# Altium schematic sheet Schematic.SchDoc
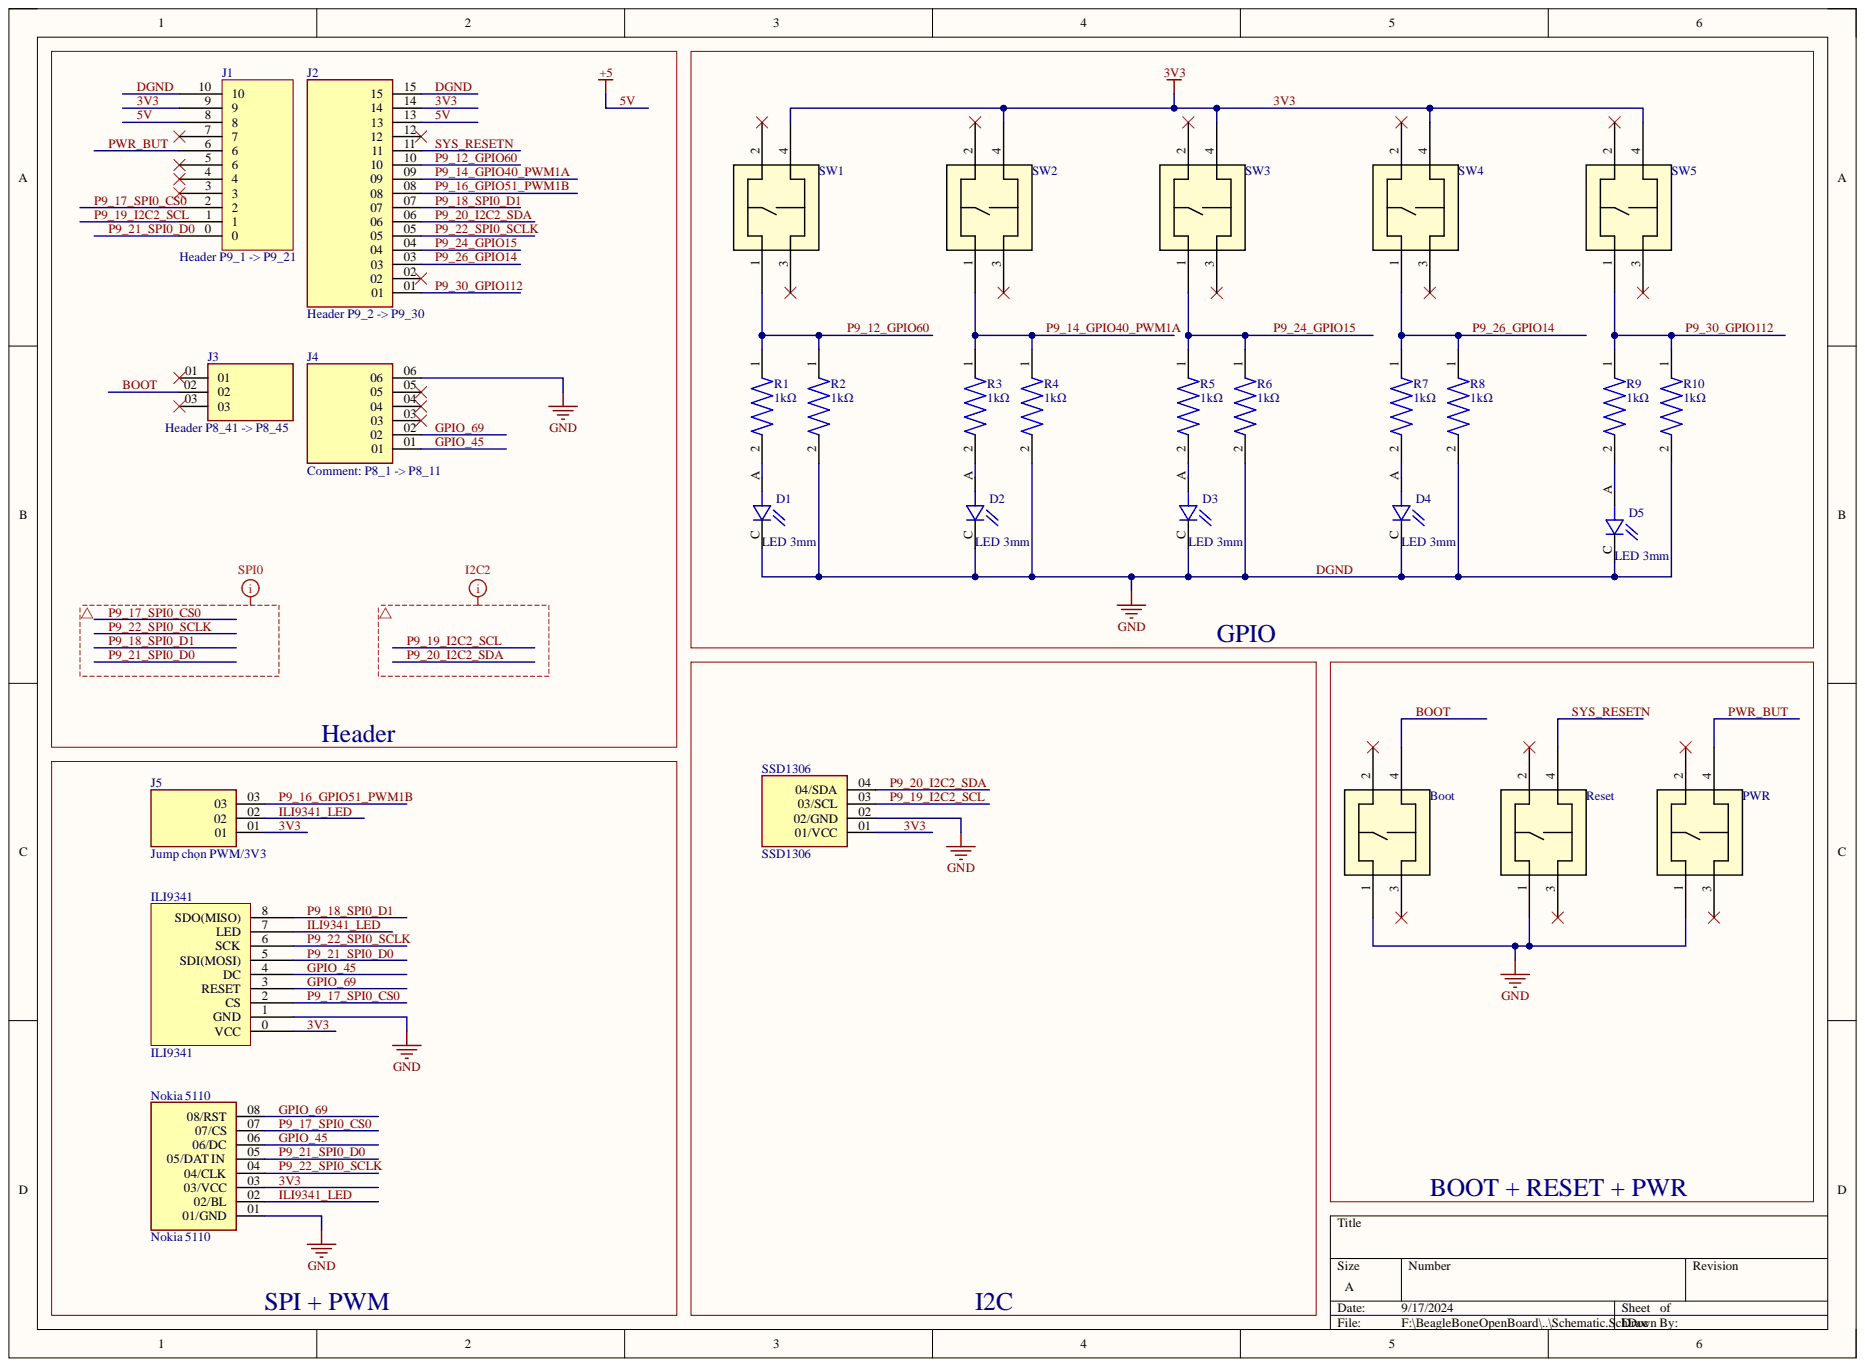
[diagram p0: Schematic.SchDoc]

## Parts
Boot  B3F-1000  [SW_B3F-1000_OMR]  (value not drawn)
D1  LED 3mm  [LEDRD254W50D305H520P]
D2  LED 3mm  [LEDRD254W50D305H520P]
D3  LED 3mm  [LEDRD254W50D305H520P]
D4  LED 3mm  [LEDRD254W50D305H520P]
D5  LED 3mm  [LEDRD254W50D305H520P]
ILI9341  ILI9341  [PCBComponent_1]
J1  Header P9_1 -> P9_21  [PCBComponent_1]
J2  Header P9_2 -> P9_30  [SAMTEC_ESQ-115-13-T-S]
J3  Header P8_41 -> P8_45  [SAMTEC_TS-103-T-A-1]
J4  P8_1 -> P8_11  [SAMTEC_MTSW-106-22-T-S-390]
J5  Jump chọn PWM/3V3  [SAMTEC_TS-103-T-A-1]
Nokia_5110  Nokia 5110  [SAMTEC_HW-08-15-T-S-375-107]
PWR  B3F-1000  [SW_B3F-1000_OMR]  (value not drawn)
R1  1kΩ  [RESAD700W45L350D185]
R2  1kΩ  [RESAD700W45L350D185]
R3  1kΩ  [RESAD700W45L350D185]
R4  1kΩ  [RESAD700W45L350D185]
R5  1kΩ  [RESAD700W45L350D185]
R6  1kΩ  [RESAD700W45L350D185]
R7  1kΩ  [RESAD700W45L350D185]
R8  1kΩ  [RESAD700W45L350D185]
R9  1kΩ  [RESAD700W45L350D185]
R10  1kΩ  [RESAD700W45L350D185]
Reset  B3F-1000  [SW_B3F-1000_OMR]  (value not drawn)
SSD1306  SSD1306  [SAMTEC_MTSW-104-09-T-S-350]
SW1  B3F-1000  [SW_B3F-1000_OMR]  (value not drawn)
SW2  B3F-1000  [SW_B3F-1000_OMR]  (value not drawn)
SW3  B3F-1000  [SW_B3F-1000_OMR]  (value not drawn)
SW4  B3F-1000  [SW_B3F-1000_OMR]  (value not drawn)
SW5  B3F-1000  [SW_B3F-1000_OMR]  (value not drawn)

## Nets
3V3: ILI9341.0(VCC) J1.9 J2.14 J5.01 Nokia_5110.03(03/VCC) SSD1306.01(01/VCC) SW1.4 SW2.4 SW3.4 SW4.4 SW5.4
5V: J1.8 J2.13
BOOT: Boot.4 J3.02
DGND: Boot.1 D1.C D2.C D3.C D4.C D5.C ILI9341.1(GND) J1.10 J2.15 J4.06 Nokia_5110.01(01/GND) PWR.1 R2.2 R4.2 R6.2 R8.2 R10.2 Reset.1 SSD1306.02(02/GND)
GPIO_45: ILI9341.4(DC) J4.01 Nokia_5110.06(06/DC)
GPIO_69: ILI9341.3(RESET) J4.02 Nokia_5110.08(08/RST)
ILI9341_LED: ILI9341.7(LED) J5.02 Nokia_5110.02(02/BL)
P9_12_GPIO60: J2.10 R1.1 R2.1 SW1.1
P9_14_GPIO40_PWM1A: J2.09 R3.1 R4.1 SW2.1
P9_16_GPIO51_PWM1B: J2.08 J5.03
P9_17_SPI0_CS0: ILI9341.2(CS) J1.2 Nokia_5110.07(07/CS)
P9_18_SPI0_D1: ILI9341.8(SDO(MISO)) J2.07
P9_19_I2C2_SCL: J1.1 SSD1306.03(03/SCL)
P9_20_I2C2_SDA: J2.06 SSD1306.04(04/SDA)
P9_21_SPI0_D0: ILI9341.5(SDI(MOSI)) J1.0 Nokia_5110.05(05/DAT_IN)
P9_22_SPI0_SCLK: ILI9341.6(SCK) J2.05 Nokia_5110.04(04/CLK)
P9_24_GPIO15: J2.04 R5.1 R6.1 SW3.1
P9_26_GPIO14: J2.03 R7.1 R8.1 SW4.1
P9_30_GPIO112: J2.01 R9.1 R10.1 SW5.1
PWR_BUT: J1.6 PWR.4
SYS_RESETN: J2.11 Reset.4
NetD1_A: D1.A R1.2
NetD2_A: D2.A R3.2
NetD3_A: D3.A R5.2
NetD4_A: D4.A R7.2
NetD5_A: D5.A R9.2

Not connected: Boot 2 3; J1 3 4 5 7; J2 02 12; J3 01 03; J4 03 04 05; PWR 2 3; Reset 2 3; SW1 2 3; SW2 2 3; SW3 2 3; SW4 2 3; SW5 2 3

## Library data of the parts
Boot PWR Reset SW1-SW5 = B3F-1000: No Description Available; Mfr_Name: Omron; Component Kind: Standard; Library Name: B3F-1000.IntLib; RefDes: RefDes; Type: Type
D1-D5 = OVLAW4CB7: White LED Indication - Discrete 3.2V Radial; MF: TT Electronics/Optek; Availability: Unavailable; Component Kind: Standard; Library Name: LED DIP 3mm.IntLib; MP: OVLAW4CB7; Package: Radial Optek; Price: None; SnapEDA_Link: https://www.snapeda.com/parts/OVLAW4CB7/TT%20Electronics/Optek%20Technology/view-part/328075/?ref=snap
ILI9341 J1 = Component_1: Component Kind: Standard
  ILI9341: Library Name: ILI9341.IntLib
  J1: Library Name: Header 11x1 2.54.IntLib
J2 = ESQ-115-13-T-S: Availability: Unavailable; Component Kind: Standard; Library Name: Header 15x1 2.54.IntLib; MP: ESQ-115-13-T-S; Package: None; Price: None
J3 J5 = TS-103-T-A-1: Connector Header position; MF: Samtec; Availability: In Stock; Check_prices: https://www.snapeda.com/parts/TS-103-T-A-1/Samtec/view-part/?ref=eda; Component Kind: Standard; Library Name: Header 1x3 2.54.IntLib; MP: TS-103-T-A-1; Package: None; Price: None; Purchase-URL: https://pricing.snapeda.com/search/part/TS-103-T-A-1/?ref=eda; SnapEDA_Link: https://www.snapeda.com/parts/TS-103-T-A-1/Samtec/view-part/?ref=snap
J4 = MTSW-106-22-T-S-390: Availability: Unavailable; Component Kind: Standard; Library Name: Header 6x1 2.54.IntLib; MP: MTSW-106-22-T-S-390; Package: None; Price: None
Nokia_5110 = HW-08-15-T-S-375-107: Availability: Unavailable; Component Kind: Standard; Library Name: Header 8x1 2.54.IntLib; MP: HW-08-15-T-S-375-107; Package: None; Price: None
R1-R10 = 6-1623927-3: CFR16 5% 10K; Availability: Unavailable; Component Kind: Standard; Library Name: resistor TH.IntLib; MP: 6-1623927-3; Package: None; Price: None
SSD1306 = MTSW-104-09-T-S-350: HEADER, 4 CONTACTS, 1 ROW, THROUGH HOLE, 2.54 MM; Connector Systems:Board-to-Board; Pitch Spacing:2.54mm; No. of Rows:1Rows; No. of Contacts:4Contacts; Contact Termination Type:Through Hole; Product Range:MTSW Series; Gender:Header RoHS Compliant: Yes; MF: Samtec; MP: MTSW10409TS350; Availability: In Stock; Check_prices: https://www.snapeda.com/parts/MTSW10409TS350/Samtec/view-part/?ref=eda; Component Kind: Standard; Library Name: Header 4x1 2.54.IntLib; Package: None; Price: None; Purchase-URL: https://pricing.snapeda.com/search?q=MTSW10409TS350&ref=eda; SnapEDA_Link: https://www.snapeda.com/parts/MTSW10409TS350/Samtec/view-part/?ref=snap
